# Altium schematic sheet DCUMain.SchDoc
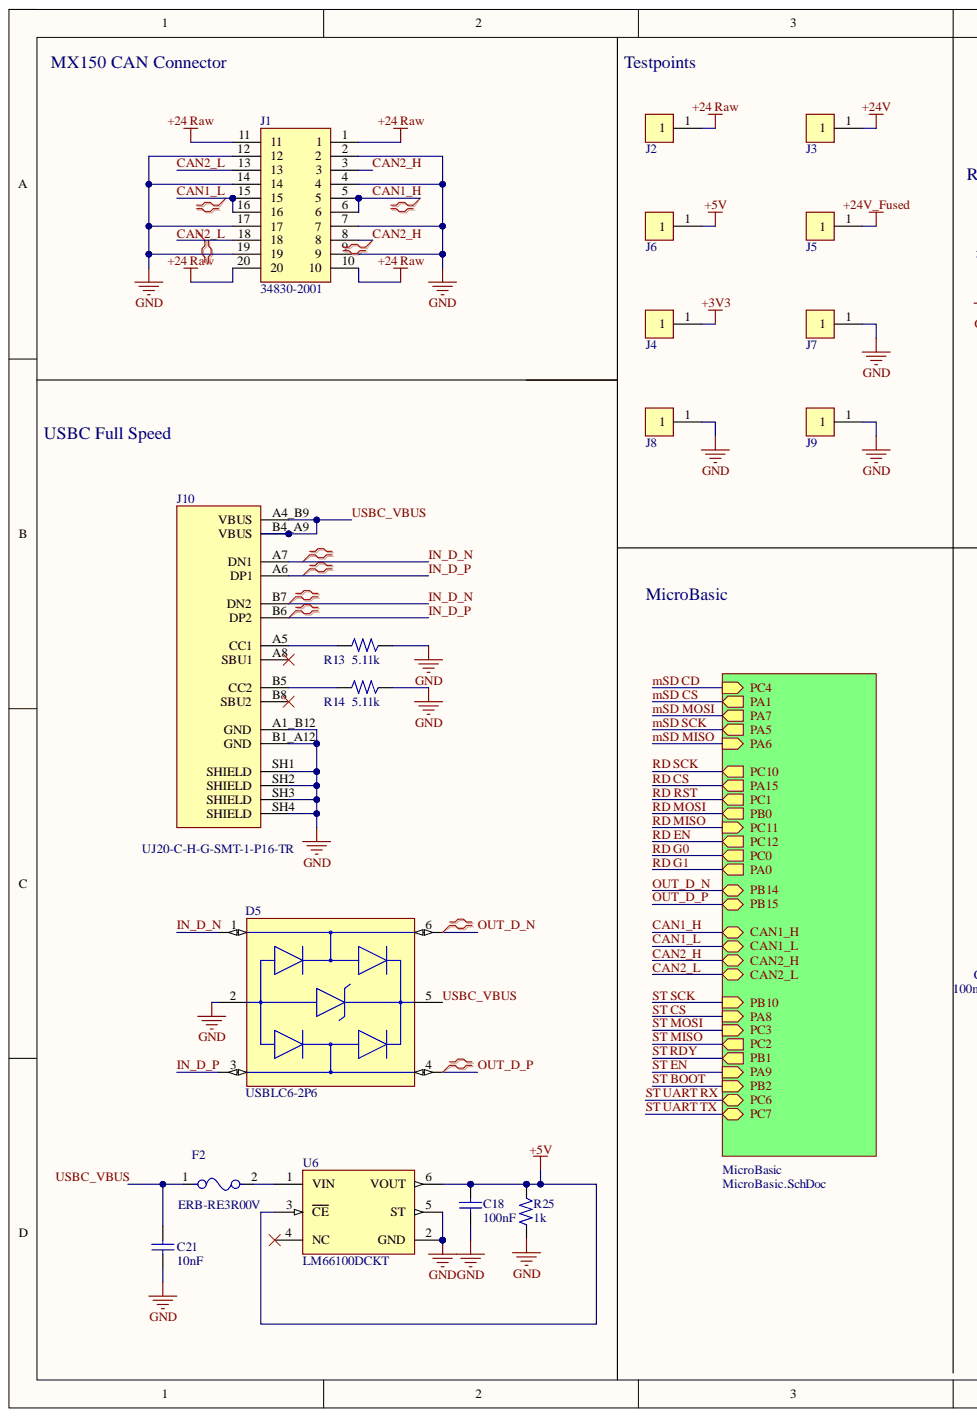
[diagram p0: DCUMain.SchDoc, left]
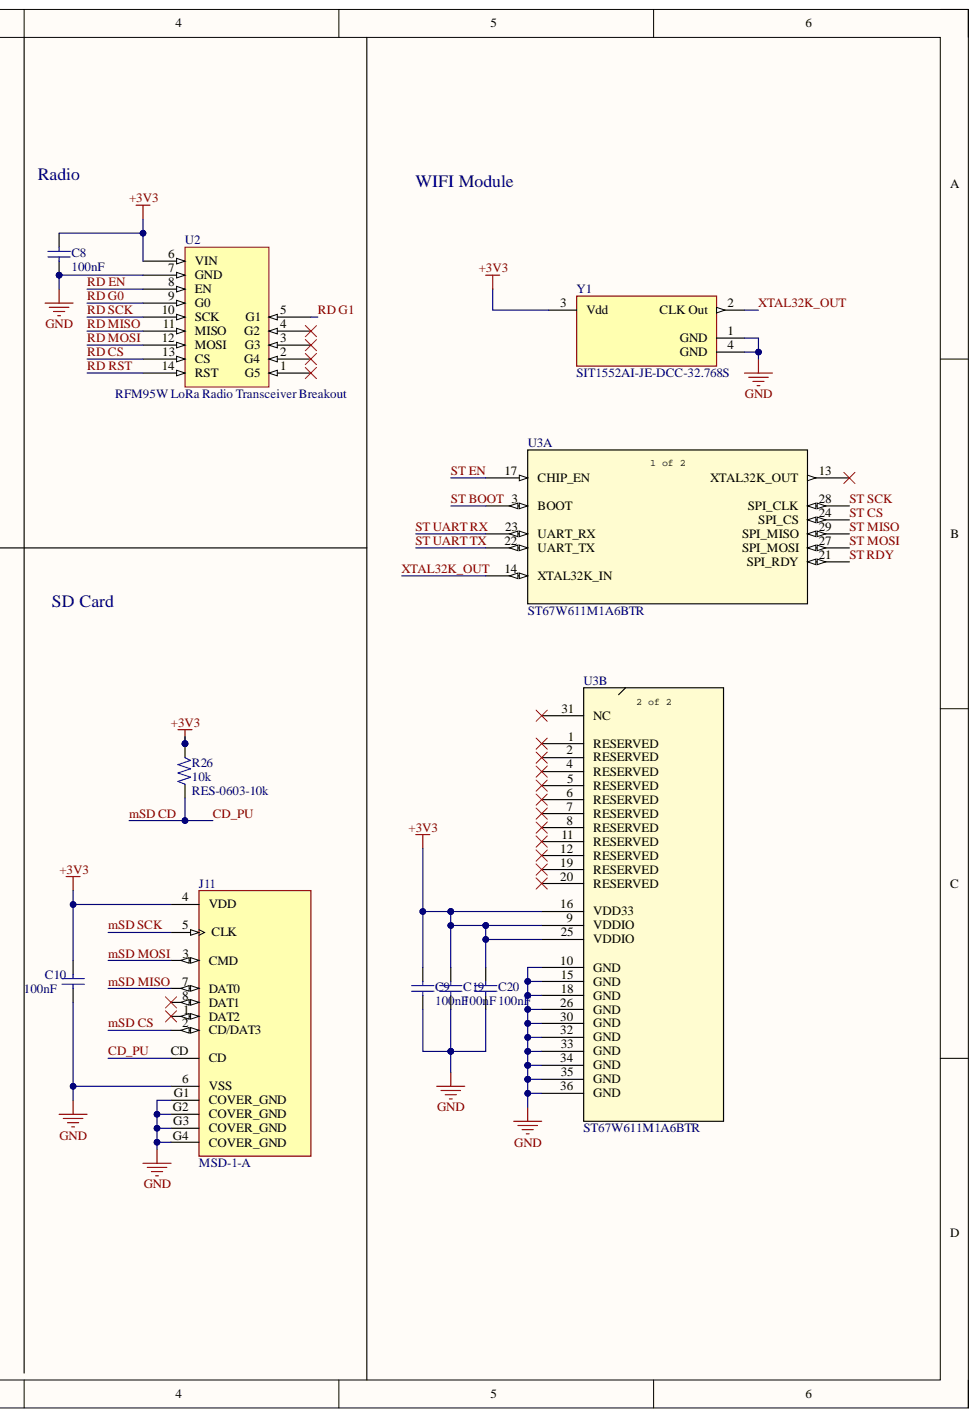
[diagram p1: DCUMain.SchDoc, right]

## Parts
C8  value 100nF  Capacitance: 100nF  [CAP0603]  (hidden comment: CAP-0603-100n-50V)
C9  value 100nF  Capacitance: 100nF  [CAP0603]  (hidden comment: CAP-0603-100n-50V)
C10  value 100nF  Capacitance: 100nF  [CAP0603]  (hidden comment: CAP-0603-100n-50V)
C18  value 100nF  Capacitance: 100nF  [CAP0603]  (hidden comment: CAP-0603-100n-50V)
C19  value 100nF  Capacitance: 100nF  [CAP0603]  (hidden comment: CAP-0603-100n-50V)
C20  value 100nF  Capacitance: 100nF  [CAP0603]  (hidden comment: CAP-0603-100n-50V)
C21  value 10nF  [CAP0603]  (hidden comment: CAP-0603-10n)
D5  USBLC6-2P6  [FP-SOT-666-MFG]
F2  ERB-RE3R00V  [FP-ERBRE-MFG]
J1  34830-2001  [348302001]
J2  CMP-2000-05181-2  [FP-5001-MFG]  (value not drawn)
J3  CMP-2000-05181-2  [FP-5001-MFG]  (value not drawn)
J4  CMP-2000-05181-2  [FP-5001-MFG]  (value not drawn)
J5  CMP-2000-05181-2  [FP-5001-MFG]  (value not drawn)
J6  CMP-2000-05181-2  [FP-5001-MFG]  (value not drawn)
J7  CMP-2000-05181-2  [FP-5001-MFG]  (value not drawn)
J8  CMP-2000-05181-2  [FP-5001-MFG]  (value not drawn)
J9  CMP-2000-05181-2  [FP-5001-MFG]  (value not drawn)
J10  UJ20-C-H-G-SMT-1-P16-TR  [SAMESKY_UJ20-C-H-G-SMT-1-P16-TR]
J11  MSD-1-A  [CUI_MSD-1-A]
R13  value 5.11k  [RES0603]  (hidden comment: RES-0603-5.11k)
R14  value 5.11k  [RES0603]  (hidden comment: RES-0603-5.11k)
R25  value 1k  [RES0603]  (hidden comment: RES-0603-1k)
R26  RES-0603-10k  value 10k  [RES0603]
U2  RFM95W LoRa Radio Transceiver Breakout  [New footprint 1]
U3  ST67W611M1A6BTR  [ST67W611M1_LGA-B_STM]  (parts A,B)
U6  LM66100DCKT  [FP-DCK0006A-IPC_C]
Y1  SIT1552AI-JE-DCC-32.768S  [FP-SiT1552-J-MFG]

## Nets
+3V3: C8.2 C9.2 C10.2 C19.2 C20.2 J4.1 J11.4(VDD) R26.2 U2.6(VIN) U3.9(VDDIO) U3.16(VDD33) U3.25(VDDIO) Y1.3(Vdd)
+5V: C18.2 J6.1 R25.2 U6.3(CE) U6.6(VOUT)
+24 Raw: J1.1 J1.10 J1.11 J1.20 J2.1
+24V: J3.1
+24V_Fused: J5.1
GND: C8.1 C9.1 C10.1 C18.1 C19.1 C20.1 C21.1 D5.2 J1.2 J1.4 J1.7 J1.9 J1.12 J1.14 J1.17 J1.19 J7.1 J8.1 J9.1 J10.A1_B12(GND) J10.B1_A12(GND) J10.SH1(SHIELD) J10.SH2(SHIELD) J10.SH3(SHIELD) J10.SH4(SHIELD) J11.6(VSS) J11.G1(COVER_GND) J11.G2(COVER_GND) J11.G3(COVER_GND) J11.G4(COVER_GND) R13.2 R14.2 R25.1 U2.7(GND) U3.10(GND) U3.15(GND) U3.18(GND) U3.26(GND) U3.30(GND) U3.32(GND) U3.33(GND) U3.34(GND) U3.35(GND) U3.36(GND) U6.2(GND) U6.5(ST) Y1.1(GND) Y1.4(GND)
CAN1_H: J1.5 J1.6
CAN1_L: J1.15 J1.16
CAN2_H: J1.3 J1.8
CAN2_L: J1.13 J1.18
CD_PU: J11.CD R26.1
IN_D_N: D5.1 J10.A7(DN1) J10.B7(DN2)
IN_D_P: D5.3 J10.A6(DP1) J10.B6(DP2)
mSD CS: J11.2(CD/DAT3)
mSD MISO: J11.7(DAT0)
mSD MOSI: J11.3(CMD)
mSD SCK: J11.5(CLK)
OUT_D_N: D5.6
OUT_D_P: D5.4
RD CS: U2.13(CS)
RD EN: U2.8(EN)
RD G0: U2.9(G0)
RD G1: U2.5(G1)
RD MISO: U2.11(MISO)
RD MOSI: U2.12(MOSI)
RD RST: U2.14(RST)
RD SCK: U2.10(SCK)
ST BOOT: U3.3(BOOT)
ST CS: U3.24(SPI_CS)
ST EN: U3.17(CHIP_EN)
ST MISO: U3.29(SPI_MISO)
ST MOSI: U3.27(SPI_MOSI)
ST RDY: U3.21(SPI_RDY)
ST SCK: U3.28(SPI_CLK)
ST UART RX: U3.23(UART_RX)
ST UART TX: U3.22(UART_TX)
USBC_VBUS: C21.2 D5.5 F2.1 J10.A4_B9(VBUS) J10.B4_A9(VBUS)
XTAL32K_OUT: U3.14(XTAL32K_IN) Y1.2(CLK_Out)
NetF2_2: F2.2 U6.1(VIN)
NetJ10_A5: J10.A5(CC1) R13.1
NetJ10_A8: J10.A8(SBU1)
NetJ10_B5: J10.B5(CC2) R14.1
NetJ10_B8: J10.B8(SBU2)
NetJ11_1: J11.1(DAT2)
NetJ11_8: J11.8(DAT1)
NetU2_1: U2.1(G5)
NetU2_2: U2.2(G4)
NetU2_3: U2.3(G3)
NetU2_4: U2.4(G2)
NetU3_1: U3.1(RESERVED)
NetU3_2: U3.2(RESERVED)
NetU3_4: U3.4(RESERVED)
NetU3_5: U3.5(RESERVED)
NetU3_6: U3.6(RESERVED)
NetU3_7: U3.7(RESERVED)
NetU3_8: U3.8(RESERVED)
NetU3_11: U3.11(RESERVED)
NetU3_12: U3.12(RESERVED)
NetU3_13: U3.13(XTAL32K_OUT)
NetU3_19: U3.19(RESERVED)
NetU3_20: U3.20(RESERVED)
NetU3_31: U3.31(NC)
NetU6_4: U6.4(NC)

## Library data of the parts
C8-C10 C18-C20 = CMP-001-00007-9: 0603 0.1uF(100n) Capacitor rated for 50V; Manufacturer Product Number: C0603C104K5RACTU; Dielectric: X7R; Tolerance: 10%; Component Kind: Standard; Digi-Key Part Number: 399-C0603C104K5RACTUCT-ND; JLCPCB Part Number: C127833; JLCPCB Part Type: Extended; Mouser Part Number: 80-C0603C104K5R; Source: CAP0603.SchLib; Voltage Rating: 50V; Library Name: Formula Electric at Berkeley
C21 = CMP-001-00022-7: 10 nF ±5% 50V Ceramic Capacitor X7R 0603 (1608 Metric); Manufacturer Product Number: 06035C103JAT2A; Component Kind: Standard; Digi-Key Part Number: 478-5007-1-ND; JLCPCB Part Number: C57112; Mouser Part Number: 581-06035C103J; Source: CAP0603.SchLib; Library Name: Formula Electric at Berkeley
D5 = CMP-12225-000058-1: TVS DIODE 5.25V 17V SOT666; Breakdown Voltage: 6V; Capacitance: 3.5pF; Direction: Unidirectional; Element Configuration: Dual; ESD Protection: Yes; Leakage Current: 150nA; Number of Bidirectional Channels: 2; Number of Elements: 1; Operating Supply Voltage: 5V; Peak Pulse Current: 5A; Test Current: 1mA; Case/Package: SOT-666; Clamping Voltage: 17V; Component Kind: Standard; Contact Plating: Tin; Depth: 1.3mm; Height: 0.6mm; Lead Free: Lead Free; Length: 1.7mm; Library Name: Altium Content Vault; Max Breakdown Voltage: 6V; Max Operating Temperature: 125°C; Max Reverse Leakage Current: 150nA; Min Breakdown Voltage: 6V; Min Operating Temperature: -40°C; Mount: Surface Mount; Number of Channels: 2; Number of Pins: 6; Package Quantity: 1; Packaging: Tape and Reel; Polarity: Unidirectional; Power Line Protection: Yes; Radiation Hardening: No; REACH SVHC: No SVHC; Reverse Breakdown Voltage: 6V; Reverse Standoff Voltage: 5V; Termination: SMD/SMT; Width: 1.3mm; Working Voltage: 5V
F2 = CMP-265-000006-2: Electric Fuse, Fast Blow, 3A, 32VDC, 50A (IR), Surface Mount, 0603; Circuit Protection Type: Electric Fuse; Component Kind: Standard; Digi-Key Part Number: P15118CT-ND; JLCPCB Part Number: C713406; JLCPCB Part Type: Extended; Manufacturer Product Number: ERB-RE3R00V; Mouser Part Number: 667-ERB-RE3R00V; Reach SVHC Compliant: Yes; RoHS Compliant: Yes; Library Name: Formula Electric at Berkeley
J1 = CMP-253-000017-2: Automotive Connectors MX150 RA HDR 2X10 ST BLK KEY A; Manufacturer_Name: Molex; Component Kind: Standard; Datasheet Link: https://www.molex.com/webdocs/datasheets/pdf/en-us/0348302001_PCB_HEADERS.pdf; Design Item ID: 34830-2001; Digi-Key Part Number: WM12752-ND; Height: 25.42mm; Manufacturer Product Number: 34830-2001; Manufacturer_Part_Number: 34830-2001; Mouser Part Number: 538-34830-2001; Mouser Price/Stock: https://www.mouser.co.uk/ProductDetail/Molex/34830-2001?qs=PdnAIJ6Mlf3cw4zbnnbtng%3D%3D; Source: SamacSys.SchLib; Library Name: Formula Electric at Berkeley
J2-J9 = CMP-504-000001-3: PC TEST POINT MINIATURE BLACK; Manufacturer: Keystone Electronics; Manufacturer Part Number: 5001; Body Material: Bronze; Hole Diameter: 1.6mm; Inside Diameter: 1.27mm; Insulation: Insulated; Number of Contacts: 1; Outside Diameter: 2.54mm; Plating: Silver; Color: Black; Component Kind: Standard; Contact Material: Bronze; Contact Plating: Silver; Design Item ID: CMP-2000-05181-2; Diameter: 2.54mm; Digi-Key Part Number: 36-5001-ND; Flammability Rating: UL94 V-0; Height: 0.3inch; Housing Color: Black; Insulation Material: Nylon; JLCPCB Part Number: C238122; JLCPCB Part Type: Extended; Lead Free: Lead Free; Length: 7.62mm; Manufacturer Product Number: 5001; Material: Nylon; Mount: Through Hole; Mouser Part Number: 534-5001; Number of Ports: 1; Orientation: Straight; Package Quantity: 100; Packaging: Bulk; REACH SVHC: No SVHC; RoHS Compliant: Yes; Series: THM; Source: PV Buck v2.SCHLIB; Termination: Through Hole; Library Name: Formula Electric at Berkeley
J10 = CMP-416-000237-1: USB-C (USB TYPE-C) USB 2.0 Receptacle Connector 24 (16+8 Dummy) Position Surface Mount, Right Angle; Through Hole; MF: Same Sky; Check_prices: https://www.snapeda.com/parts/UJ20-C-H-G-SMT-1-P16-TR/Same+Sky/view-part/?ref=eda; Component Kind: Standard; Component Type: Standard; Design Item ID: UJ20-C-H-G-SMT-1-P16-TR; MP: UJ20-C-H-G-SMT-1-P16-TR; Package: None; Pin Count: 16; SnapEDA_Link: https://www.snapeda.com/parts/UJ20-C-H-G-SMT-1-P16-TR/Same+Sky/view-part/?ref=snap; Source: UJ20-C-H-G-SMT-1-P16-TR.SchLib; Library Name: Formula Electric at Berkeley
J11 = CMP-416-000232-2: Micro SD Card Connector, 9 Positions, Connector and Ejector, Push In, Auto Eject Out, Surface Mount, 1.8 mm Height Above Board, Gold Flash; MF: Same Sky; Check_prices: https://www.snapeda.com/parts/MSD-1-A/Same+Sky/view-part/?ref=eda; Component Kind: Standard; Component Type: Standard; Design Item ID: MSD-1-A; Digi-Key Part Number: 2223-MSD-1-ACT-ND; Manufacturer Product Number: MSD-1-A; Mouser Part Number: 179-MSD-1-A; MP: MSD-1-A; Package: None; Pin Count: 13; SnapEDA_Link: https://www.snapeda.com/parts/MSD-1-A/Same+Sky/view-part/?ref=snap; Source: MSD-1-A.SchLib; Library Name: Formula Electric at Berkeley
R13 R14 = CMP-009-00046-1: 0603 5.11kOhm Resistor; Manufacturer Product Number: RC1608F102CS; Tolerance: 1%; Component Kind: Standard; Digi-Key Part Number: 13-RC0603FR-135K11LCT-ND; JLCPCB Part Number: C705927; JLCPCB Part Type: Extended; Mouser Part Number: 603-RC0603FR-135K11L; Source: RES0603.SchLib; Library Name: Formula Electric at Berkeley
R25 = CMP-009-00012-4: 0603 1kOhm Resistor; Manufacturer Product Number: ERJ-3EKF1001V; Tolerance: 1%; Voltage Rating: 50V; Component Kind: Standard; Digi-Key Part Number: P1.00KHCT-ND; JLCPCB Part Number: C118155; JLCPCB Part Type: Extended; Mouser Part Number: 667-ERJ-3EKF1001V; Source: RES0603.SchLib; Library Name: Formula Electric at Berkeley
R26 = CMP-009-00008-5: Resistor 0603 10k Ohm 1% 1/8W; Manufacturer Product Number: ERJ-3EKF1002V; Component Kind: Standard; Digi-Key Part Number: P10.0KHCT-ND; JLCPCB Part Number: C191124; JLCPCB Part Type: Extended; Mouser Part Number: 667-ERJ-3EKF1002V; Source: RES0603.SchLib; Tolerance: 1%; Library Name: Formula Electric at Berkeley
U2 = CMP-00111-2: Adafruit Rfm95W Lora Radio Transceiver Breakout - 868 Or 915 Mhz - Radiofruit; Manufacturer: Adafruit Industries; Manufacturer Product Number: 3072; Evaluation Kit: Yes; Frequency: 915MHz; Component Kind: Standard; Datasheet: https://learn.adafruit.com/adafruit-rfm69hcw-and-rfm96-rfm95-rfm98-lora-packet-padio-breakouts/overview; Digi-Key Part Number: 1528-1667-ND; Mouser Part Number: 485-3072; RoHS: Non-Compliant; Schedule B: 8473300002, 8473300002; Library Name: Formula Electric at Berkeley
U3 = CMP-1266-000000-2: Low-power Wi-Fi 6 & Bluetooth LE combo coprocessor module; Manufacturer_Name: STMicroelectronics; Component Kind: Standard; Design Item ID: ST67W611M1A6BTR; Digi-Key Part Number: 497-ST67W611M1A6BTRCT-ND; Manufacturer Product Number: ST67W611M1A6BTR; Manufacturer_Part_Number: ST67W611M1A6BTR; Mouser Part Number: 511-ST67W611M1A6BTR; RefDes: RefDes; Source: ST67W611M1A6BTR.SchLib; Type: Type; Copyright: Copyright (C) 2026 Ultra Librarian. All rights reserved.; Library Name: Formula Electric at Berkeley
U6 = CMP-04910-000059-1: IC OR CTRLR IDEAL DIODE SC70-6; Manufacturer: Texas Instruments; Component Kind: Standard; Digi-Key Part Number: 296-53540-1-ND; JLCPCB Part Number: C2832141; JLCPCB Part Type: Extended; Library Name: Altium Content Vault; Manufacturer Product Number: LM66100DCKT; Mouser Part Number: 595-LM66100DCKT
Y1 = CMP-25159-259504-1: MEMS OSC TCXO 32.7680KHZ LVCMOS; Frequency: 32.768kHz; Frequency Stability: 5ppm; Max Supply Current: 1.52uA; Component Kind: Standard; Library Name: Altium Content Vault; Max Operating Temperature: 85°C; Min Operating Temperature: -40°C; Mount: Surface Mount; Packaging: Tape and Reel; RoHS Compliant: Yes; Series: SiT1552
